# Altium schematic sheet Module_Wi-Fi.SchDoc
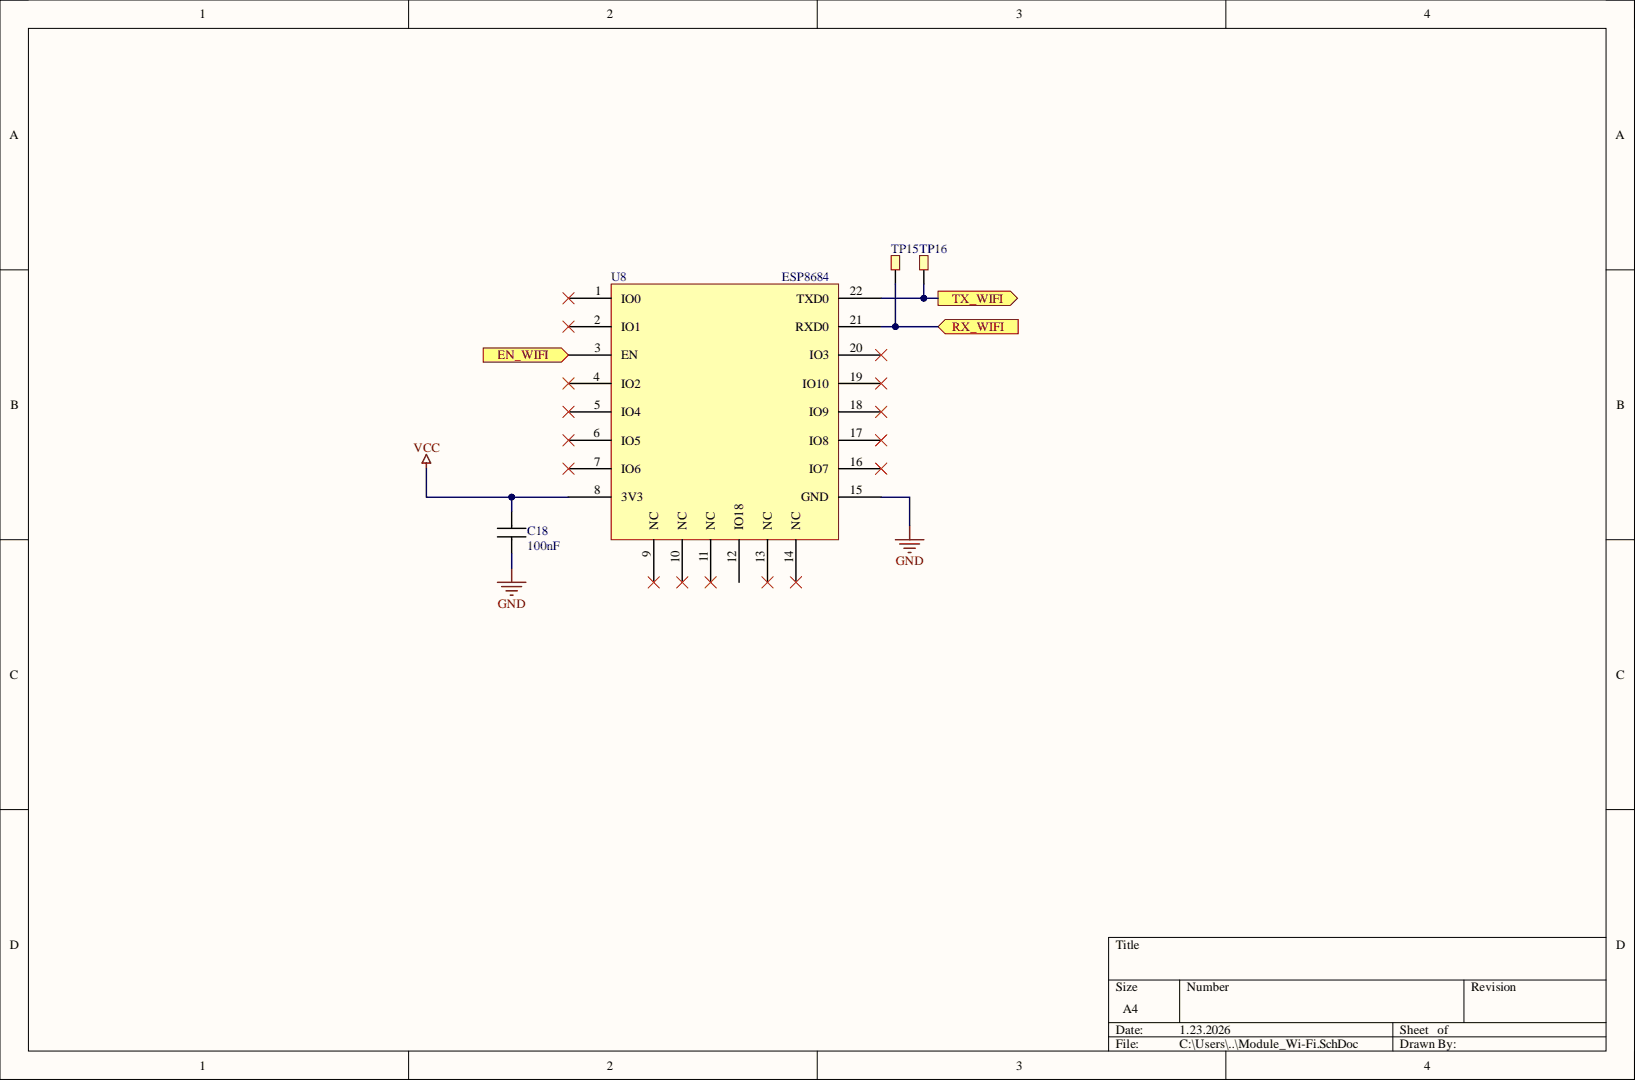
[diagram p0: Module_Wi-Fi.SchDoc]

## Parts
C18  100nF  [CAPC1608X08M]
TP15  5019  [TESTPOINT_SMD]  (value not drawn)
TP16  5019  [TESTPOINT_SMD]  (value not drawn)
U8  ESP8684

## Ports
EN_WIFI, RX_WIFI, TX_WIFI

## Nets
GND: C18.1 U8.15(GND)
VCC: C18.2 U8.8(3V3)
EN_WIFI: U8.3(EN) ; port EN_WIFI
RX_WIFI: TP15.1 U8.21(RXD0) ; port RX_WIFI
TX_WIFI: TP16.1 U8.22(TXD0) ; port TX_WIFI

Not connected: U8 1(IO0) 2(IO1) 4(IO2) 5(IO4) 6(IO5) 7(IO6) 9(NC) 10(NC) 11(NC) 12(IO18) 13(NC) 14(NC) 16(IO7) 17(IO8) 18(IO9) 19(IO10) 20(IO3)

## Library data of the parts
C18 = CMP-065-000000-2: CAPC 100nF X7R 50V 10% 1608 (0603 INCH); Manufacturer: TDK; Manufacturer Number: CGA3E2X7R1H104K080AA; Current: NULL; IsStocked: 1; PN: PN-338083; TemperatureRange: -55°C to +125°C; Category: Passives; Component Kind: Standard; Dielectric: X7R; Library Name: ETML-ES; Power: NULL; SubCategory: Condensateurs ceramique; Supplier 1: ETML; Supplier Part Number 1: FAB.0603_C 100n; Supplier Price 1: 0.0100; TemperatureCoeff: NULL; Tolerance: ±10%; Value: 100nF; Voltage: 50V
TP15 TP16 = TESTPOINT: Test point smd; Component Kind: Standard; Supplier 1: Mouser; Supplier Part Number 1: 534-5019; Library Name: 2008_SablierElectronique_SCHLIB.SchLib
U8 = ESP8684: Component Kind: Standard; Library Name: Schlib1.SchLib
